# Altium schematic sheet ind3200.SchDoc
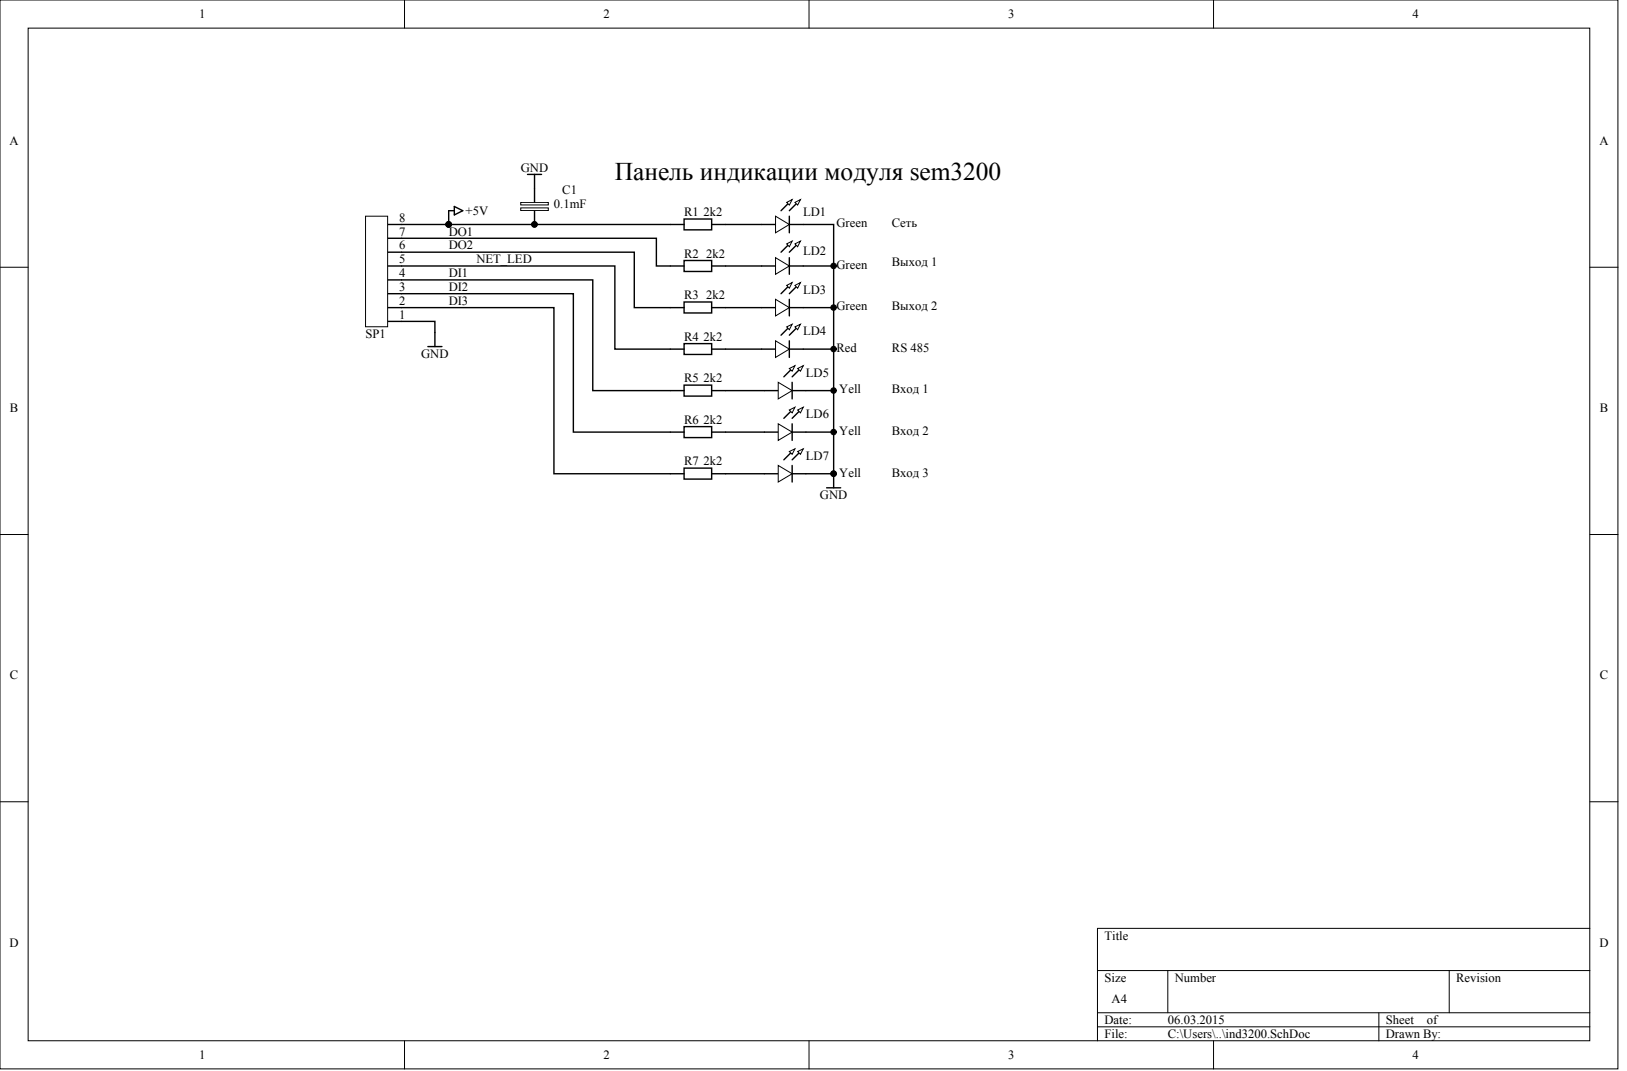
[diagram p0: ind3200.SchDoc]

## Parts
C1  0.1  value 0.1mF
LD1  Green
LD2  Green
LD3  Green
LD4  Red
LD5  Yell
LD6  Yell
LD7  Yell
R1  value 2k2  (hidden comment: 1k)
R2  value 2k2  (hidden comment: 1k)
R3  value 2k2  (hidden comment: 1k)
R4  value 2k2  (hidden comment: 1k)
R5  value 2k2  (hidden comment: 1k)
R6  value 2k2  (hidden comment: 1k)
R7  value 2k2  (hidden comment: 1k)
SP1  SP1

## Nets
+5V: C1.2 R1.2 SP1.8
GND: C1.1 LD1.2 LD2.2 LD3.2 LD4.2 LD5.2 LD6.2 LD7.2 SP1.1
DI1: R5.2 SP1.4
DI2: R6.2 SP1.3
DI3: R7.2 SP1.2
DO1: R2.2 SP1.7
DO2: R3.2 SP1.6
NET_LED: R4.2 SP1.5
NetLD1_1: LD1.1 R1.1
NetLD2_1: LD2.1 R2.1
NetLD3_1: LD3.1 R3.1
NetLD4_1: LD4.1 R4.1
NetLD5_1: LD5.1 R5.1
NetLD6_1: LD6.1 R6.1
NetLD7_1: LD7.1 R7.1

## Library data of the parts
LD1-LD3 = (no symbol name): Type: L-1154GD
LD4 = (no symbol name): Type: BL-B4541Q R
LD5-LD7 = (no symbol name): Type: L-1154YD
C1 R1-R7 SP1 = (no symbol name)
  SP1: Type: PLS-8 (DS1021-1x8)
